# Altium schematic sheet Ethernet.SchDoc(Ethernet)
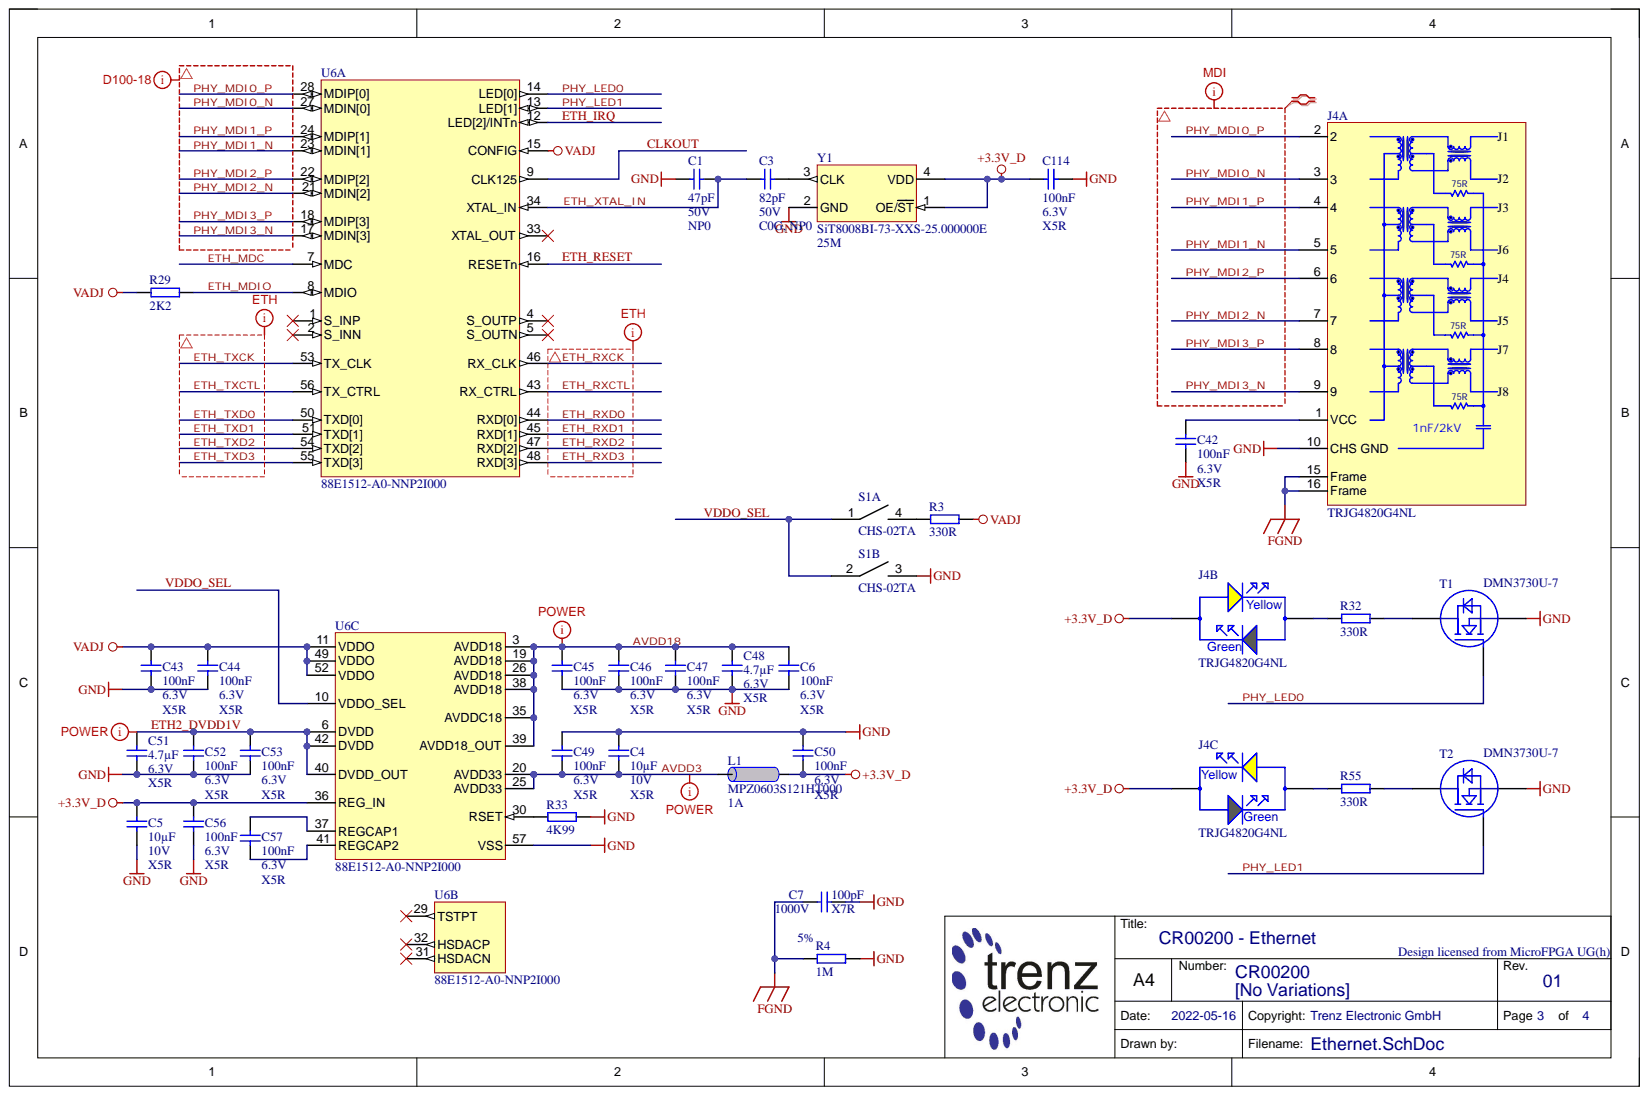
[diagram p0: Ethernet.SchDoc]

## Parts
C1  47pF  Voltage: 50V  [CAPC1005X55N_V]
C3  82pF  [CAPC1005X55N_V]
C4  10µF  Technology: X5R  Voltage: 10V  [CAPC1005X55N_V]
C5  10µF  Technology: X5R  Voltage: 10V  [CAPC1005X55N_V]
C6  100nF  Technology: X5R  Voltage: 6.3V  [CAPC0603X33N_V]
C7  100pF  Technology: X7R  Voltage: 1000V  [CAPC3216X180N_V]
C42  100nF  Technology: X5R  Voltage: 6.3V  [CAPC0603X33N_V]
C43  100nF  Technology: X5R  Voltage: 6.3V  [CAPC0603X33N_V]
C44  100nF  Technology: X5R  Voltage: 6.3V  [CAPC0603X33N_V]
C45  100nF  Technology: X5R  Voltage: 6.3V  [CAPC0603X33N_V]
C46  100nF  Technology: X5R  Voltage: 6.3V  [CAPC0603X33N_V]
C47  100nF  Technology: X5R  Voltage: 6.3V  [CAPC0603X33N_V]
C48  4.7µF  Technology: X5R  Voltage: 6.3V  [CAPC1005X55N_V]
C49  100nF  Voltage: 6.3V  [CAPC0603X33N_V]
C50  100nF  Technology: X5R  Voltage: 6.3V  [CAPC0603X33N_V]
C51  4.7µF  Technology: X5R  Voltage: 6.3V  [CAPC1005X55N_V]
C52  100nF  Voltage: 6.3V  [CAPC0603X33N_V]
C53  100nF  Technology: X5R  Voltage: 6.3V  [CAPC0603X33N_V]
C56  100nF  Technology: X5R  Voltage: 6.3V  [CAPC0603X33N_V]
C57  100nF  Technology: X5R  Voltage: 6.3V  [CAPC0603X33N_V]
C114  100nF  Voltage: 6.3V  [CAPC0603X33N_V]
J4  TRJG4820G4NL  [MIC38013-5151T-LF3]  (parts A,B,C)
L1  MPZ0603S121HT000  Voltage: 1A  [INDC0603X33N_V]
R3  330R  [RESC1005X40N_V]
R4  1M  Tolerance: 5%  [RESC3216X65N_V]
R29  2K2  [RESC1005X40N_V]
R32  330R  [RESC1005X40N_V]
R33  4K99  [RESC1005X40N_V]
R55  330R  [RESC1005X40N_V]
S1  CHS-02TA  [CHS-02TA]  (parts A,B)
T1  DMN3730U-7  [SOT95P230X109-3N]
T2  DMN3730U-7  [SOT95P230X109-3N]
U6  88E1512-A0-NNP2I000  [QFN50P800X800X100-57N]  (parts A,B,C)
Y1  SiT8008BI-73-XXS-25.000000E  value 25M  Database Table Name: CLK  [2016]

## Nets
+3.3V_D: C5.1 C50.2 C56.1 C114.1 J4.12 J4.13 L1.1 U6.36(REG_IN) Y1.1(OE/ST) Y1.4(VDD)
FGND: C7.1 J4.15(Frame) J4.16(Frame) R4.1
GND: C1.1 C4.1 C5.2 C6.2 C7.2 C42.1 C43.2 C44.2 C45.2 C46.2 C47.2 C48.2 C49.1 C50.1 C51.2 C52.2 C53.2 C56.2 C114.2 J4.10(CHS_GND) R4.2 R33.2 S1.3 T1.2 T2.2 U6.57(VSS) Y1.2(GND)
VADJ: C43.1 C44.1 R3.2 R29.1 U6.11(VDDO) U6.15(CONFIG) U6.49(VDDO) U6.52(VDDO)
AVDD3: C4.2 C49.2 L1.2 U6.20(AVDD33) U6.25(AVDD33)
AVDD18: C6.1 C45.1 C46.1 C47.1 C48.1 U6.3(AVDD18) U6.19(AVDD18) U6.26(AVDD18) U6.35(AVDDC18) U6.38(AVDD18) U6.39(AVDD18_OUT)
CLKOUT: U6.9(CLK125)
ETH2_DVDD1V: C51.1 C52.1 C53.1 U6.6(DVDD) U6.40(DVDD_OUT) U6.42(DVDD)
ETH_IRQ: U6.12(LED[2]/INTn)
ETH_MDC: U6.7(MDC)
ETH_MDIO: R29.2 U6.8(MDIO)
ETH_RESET: U6.16(RESETn)
ETH_RXCK: U6.46(RX_CLK)
ETH_RXCTL: U6.43(RX_CTRL)
ETH_RXD0: U6.44(RXD[0])
ETH_RXD1: U6.45(RXD[1])
ETH_RXD2: U6.47(RXD[2])
ETH_RXD3: U6.48(RXD[3])
ETH_TXCK: U6.53(TX_CLK)
ETH_TXCTL: U6.56(TX_CTRL)
ETH_TXD0: U6.50(TXD[0])
ETH_TXD1: U6.51(TXD[1])
ETH_TXD2: U6.54(TXD[2])
ETH_TXD3: U6.55(TXD[3])
ETH_XTAL_IN: C1.2 C3.1 U6.34(XTAL_IN)
PHY_LED0: T1.1 U6.14(LED[0])
PHY_LED1: T2.1 U6.13(LED[1])
PHY_MDI0_N: J4.3 U6.27(MDIN[0])
PHY_MDI0_P: J4.2 U6.28(MDIP[0])
PHY_MDI1_N: J4.5 U6.23(MDIN[1])
PHY_MDI1_P: J4.4 U6.24(MDIP[1])
PHY_MDI2_N: J4.7 U6.21(MDIN[2])
PHY_MDI2_P: J4.6 U6.22(MDIP[2])
PHY_MDI3_N: J4.9 U6.17(MDIN[3])
PHY_MDI3_P: J4.8 U6.18(MDIP[3])
VDDO_SEL: S1.1 S1.2 U6.10(VDDO_SEL)
NetC3_2: C3.2 Y1.3(CLK)
NetC42_2: C42.2 J4.1(VCC)
NetC57_1: C57.1 U6.37(REGCAP1)
NetC57_2: C57.2 U6.41(REGCAP2)
NetJ4_11: J4.11 R32.1
NetJ4_14: J4.14 R55.1
NetR3_1: R3.1 S1.4
NetR32_2: R32.2 T1.3
NetR33_1: R33.1 U6.30(RSET)
NetR55_2: R55.2 T2.3

Not connected: U6 1(S_INP) 2(S_INN) 4(S_OUTP) 5(S_OUTN) 29(TSTPT) 31(HSDACN) 32(HSDACP) 33(XTAL_OUT)

## Library data of the parts
C1 = 28905: KON 47pF 50V NP0 1% SMD  BF 1005 (0402); Database Table Name: C_1005_0402; Mixed: SMT; Value: 47p; AN: 28905; Case: 1005 (0402); Component Kind: Standard; Library Name: TE.DbLib; Technology: NP0; Tolerance: 1%
C3 = 28222: KON 82pF 50V NP0 ±1%  SMD BF 1005 (0402); Database Table Name: C_1005_0402; Mixed: SMT; Value: 82p; AN: 28222; Case: 1005 (0402); Component Kind: Standard; Library Name: TE.DbLib; Technology: C0G, NP0; Tolerance: ±1%; Voltage: 50V
C4 C5 = 30683: KON 10µF 10V X5R 20% SMD BF 1005 (0402); Database Table Name: C_1005_0402; Mixed: SMT; Temperature-Range: -55/+85; Value: 10u; AN: 30683; Case: 1005 (0402); Component Kind: Standard; Library Name: TE.DbLib; Tolerance: 20%
C6 C42-C47 C49 C50 C52 C53 C56 C57 C114 = 24915: KON 100nF 6.3V X5R 10% SMD BF 0603 (0201); Database Table Name: C_0603_0201; Mixed: SMT; Preferred: Yes; Temperature-Range: -55/+85; Value: 100n; AN: 24915; Case: 0603 (0201); Component Kind: Standard; Library Name: TE.DbLib; Tolerance: 10%
  C49 C52 C114: Technology: X5R
C7 = 22679: KON 100pF 1000V X7R 10%  BF 3216 (1206); Database Table Name: C_3216_1206; Mixed: SMT; Preferred: Yes; Temperature-Range: -55/+125; Value: 100p; AN: 22679; Case: 3216 (1206); Component Kind: Standard; Library Name: TE.DbLib; Tolerance: 10%
C48 C51 = 24719: KON 4.7µF 6.3V X5R 20% SMD BF 1005 (0402); Database Table Name: C_1005_0402; Mixed: SMT; Preferred: Yes; Temperature-Range: -55/+85; Value: 4.7u; AN: 24719; Case: 1005 (0402); Component Kind: Standard; Library Name: TE.DbLib; Tolerance: 20%
J4 = 27357: LAN-Transformer 10/100/1000 BaseT; Database Table Name: CON_IO; Extra: TRJG4820G4NL, -40 to +85 °C,; Mixed: THT; Temperature-Range: -40/+85; Trenz-Stock: Yes; AN: 27357; Component Kind: Standard; H-ART: TRJG4820G4NL; Library Name: TE.DbLib; Technology: -40 to +85 °C; HelpURL: B:\ad\adlib\datasheet\TRJG4820G4NL
L1 = 30910: Ferritbead MPZ0603S121HT000; Database Table Name: L_0603_0201; Extra: 0,13 Ohm DC, 120 Ohm@100MHz. 1A , 25%; Mixed: SMT; Preferred: Yes; Temperature-Range: -55/+85; Tolerance: 25%; Value: 120 Ohm; AN: 30910; Case: 0603 (0201); Component Kind: Standard; H-ART: MPZ0603S121HT000; Library Name: TE.DbLib; Storage-Temperature: -55/+85; Technology: 0.13 Ohm; Trenz-Stock: Yes; HelpURL: b:\AD\adlib\datasheet\Murata\MPZ0603-H\
R3 R32 R55 = 22762: WID SMD 330R 1% 0,063W  BF 1005 (0402); Database Table Name: R_1005_0402; H-ART: RC0402FR-13330RL; Mixed: SMT; Preferred: Yes; Value: 330; Voltage: 0.063W; AN: 22762; Case: 1005 (0402); Component Kind: Standard; Library Name: TE.DbLib; Technology: TK100; Tolerance: 1%
R4 = 22757: WID SMD 1M 5% 0,25W  BF 3216 (1206); Database Table Name: R_3216_1206; Mixed: SMT; Preferred: Yes; AN: 22757; Case: 3216 (1206); Component Kind: Standard; Library Name: TE.DbLib; Technology: TK100; Value: 1M
R29 = 22748: WID SMD 2K2 1% 0,063W BF 1005 (0402); Database Table Name: R_1005_0402; H-ART: RC0402FR-132K2L; Mixed: SMT; Preferred: Yes; Value: 2.2K; Voltage: 0.063W; AN: 22748; Case: 1005 (0402); Component Kind: Standard; Library Name: TE.DbLib; Technology: TK100; Tolerance: 1%
R33 = 22754: WID SMD 4K99 1% 0,063W BF 1005 (0402); Database Table Name: R_1005_0402; H-ART: RC0402FR-074K99L; Mixed: SMT; Preferred: Yes; Value: 4.99K; Voltage: 0.063W; AN: 22754; Case: 1005 (0402); Component Kind: Standard; Library Name: TE.DbLib; Technology: TK100; Tolerance: 1%
S1 = 23416: DIP Schalter 2 fach 1,27mm SMD; Database Table Name: PB_SW; Mixed: SMT; Trenz-Stock: Yes; AN: 23416; Component Kind: Standard; Extra: CHS-02TA; H-ART: CHS-02TA; Library Name: TE.DbLib; HelpURL: B:\AD\adlib\datasheet\CHS
T1 T2 = 29802: Database Table Name: TRANSISTOR; Extra: MOSFET N-Channel 30 V 750mA (Ta) 450mW (Ta) SMT SOT-23-3; Mixed: SMT; Preferred: Yes; Temperature-Range: -55/+155(Tj Abs); Value: 0.85A; Voltage: 30V; AN: 29802; Case: SOT23-3; Component Kind: Standard; Description: DMN3730U-7; H-ART: DMN3730U-7; Library Name: TE.DbLib; Storage-Temperature: -55/+155(Abs); Technology: N-Channel; Trenz-Stock: Yes; HelpURL: b:\AD\adlib\datasheet\DMN3730U\
U6 = 24652: Database Table Name: IF; Extra: Energy Efficient Gigabit Ethernet Transceiver, Industrial; Mixed: SMT; Preferred: Yes; Temperature-Range: -40/+85; AN: 24652; Case: QFN56; Component Kind: Standard; Description: 88E1512-A0-NNP2I000; H-ART: 88E1512-A0-NNP2I000; Library Name: TE.DbLib; Storage-Temperature: -55/+125 (Abs); Trenz-Stock: Yes; HelpURL: B:\AD\adlib\datasheet\NDA\88E1512
Y1 = 30736: SiT8008BI-73-XXS-25.000000E MEMS Oszillator 25MHz; Extra: 2,25 V bis 3,63 V , -40° C - 85° C, +/-50 ppm, 2 x1,6 mm; Mixed: SMT; Temperature-Range: -40/+85; Trenz-Stock: Yes; Voltage: 2.5-3.3V; AN: 30736; Case: 2.0 x 1.6mm; Component Kind: Standard; H-ART: SiT8008BI-73-XXS-25.000000E; Library Name: TE.DbLib; Storage-Temperature: -65/+150 (Abs); HelpURL: B:\AD\adlib\datasheet\SiT8008
